# Altium schematic sheet Sheet1.SchDoc(Sheet1)
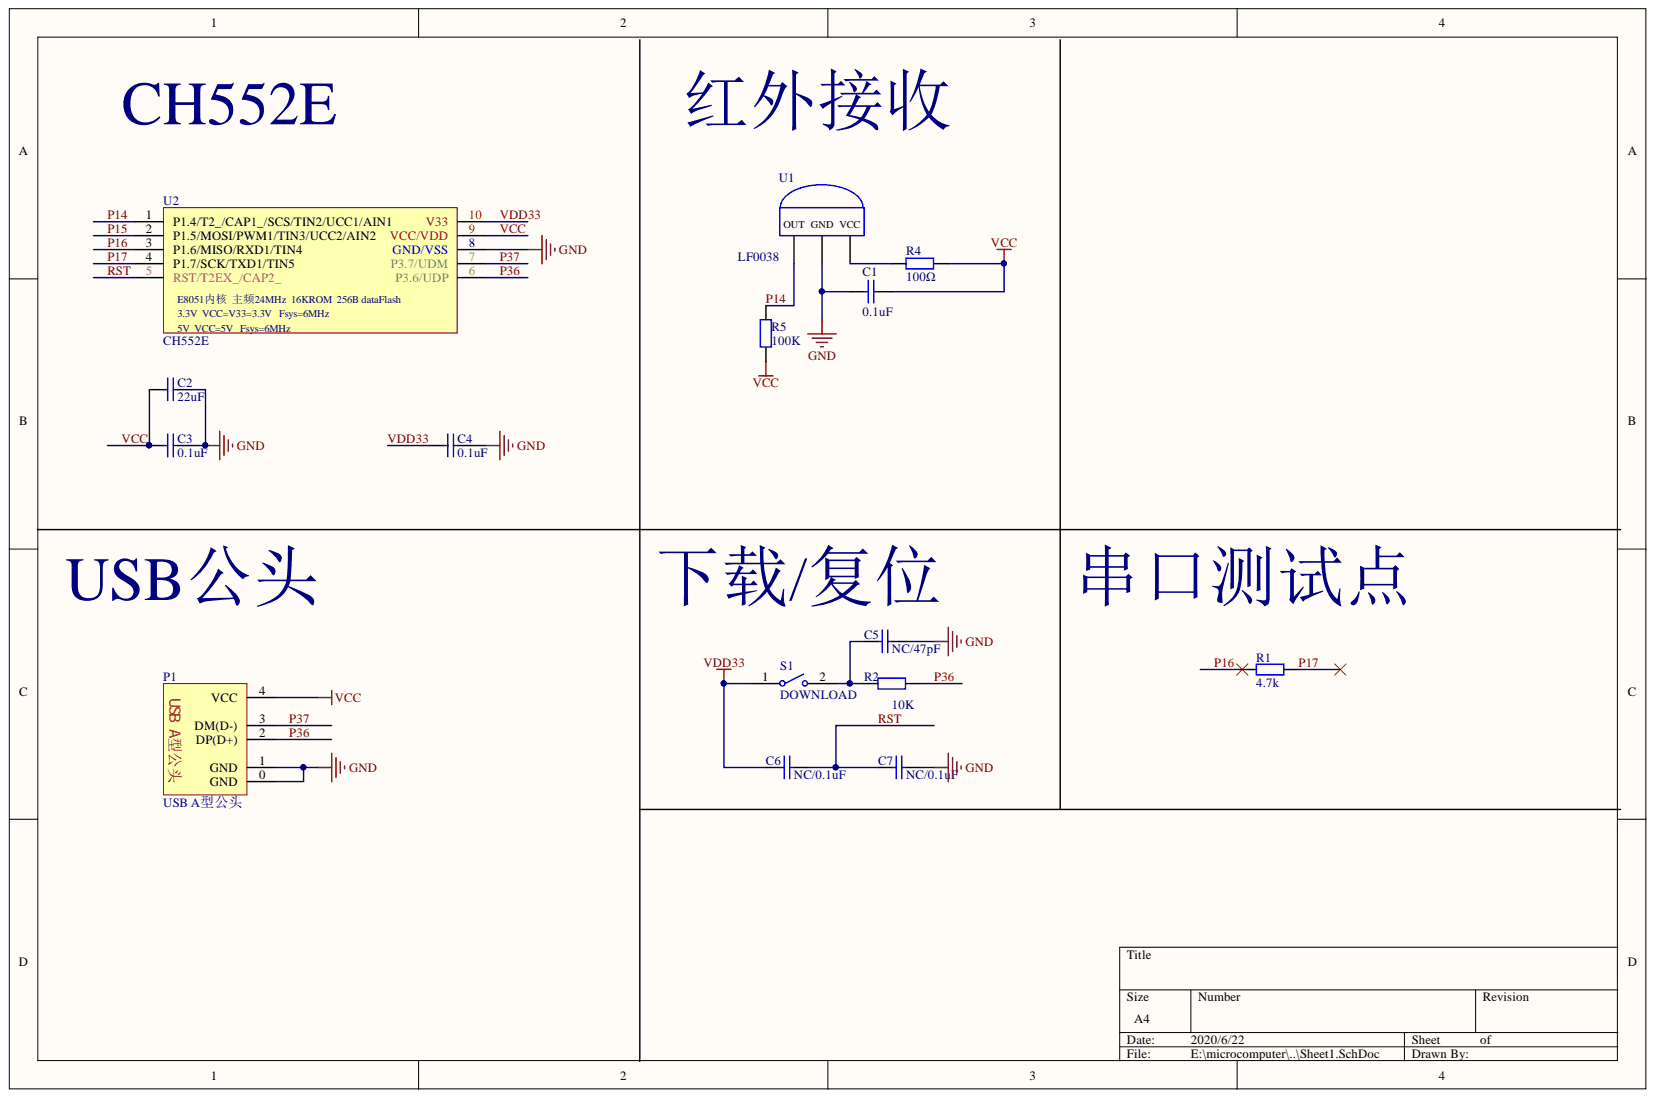
[diagram p0: Sheet1.SchDoc]

## Parts
C1  0.1uF  [0603_C]
C2  22uF  [0805_C]
C3  0.1uF  [0603_C]
C4  0.1uF  [0603_C]
C5  NC/47pF  [0603_C]
C6  NC/0.1uF  [0603_C]
C7  NC/0.1uF  [0603_C]
P1  USB A型公头  [USB_A型公头双面插]
R1  4.7k  [0603_R]
R2  10K  [0603_R]
R4  100Ω  [0603_R]
R5  100K  [0603_R]
S1  DOWNLOAD  [Switch_3*4*2]
U1  LF0038  [4mm贴片红外接收头]
U2  CH552E  [MSOP-10]

## Nets
GND: C1.2 C2.2 C3.2 C4.2 C5.2 C7.2 P1.0(GND) P1.1(GND) U1.2 U2.8(GND/VSS)
P14: R5.2 U1.3 U2.1(P1.4/T2_/CAP1_/SCS/TIN2/UCC1/AIN1)
P15: U2.2(P1.5/MOSI/PWM1/TIN3/UCC2/AIN2)
P16: R1.1 U2.3(P1.6/MISO/RXD1/TIN4)
P17: R1.2 U2.4(P1.7/SCK/TXD1/TIN5)
P36: P1.2(DP(D+)) R2.2 U2.6(P3.6/UDP)
P37: P1.3(DM(D-)) U2.7(P3.7/UDM)
RST: C6.2 C7.1 U2.5(RST/T2EX_/CAP2_)
VCC: C1.1 C2.1 C3.1 P1.4(VCC) R4.2 R5.1 U2.9(VCC/VDD)
VDD33: C4.1 C6.1 S1.1 U2.10(V33)
NetC5_1: C5.1 R2.1 S1.2
NetR4_1: R4.1 U1.1

## Library data of the parts
C1-C7 = Cap: Value: 100pF; Published: 8-Jun-2000; PackageReference: RAD-0.3; PackageDescription: Radial Cap, Thru-Hole; 2 Leads; 0.3 in Pin Spacing; LatestRevisionNote: Re-released for DXP Platform.; LatestRevisionDate: 17-Jul-2002; Component Kind: Standard; Publisher: Altium Limited; Library Name: 基础元件库.SchLib
R1 R2 R4 R5 = Res: 电阻; Value: 1K; Published: 8-Jun-2000; PackageReference: AXIAL-0.4; PackageDescription: Axial Device, Thru-Hole; 2 Leads; 0.4 in Pin Spacing; LatestRevisionNote: Re-released for DXP Platform.; LatestRevisionDate: 17-Jul-2002; Component Kind: Standard; Publisher: Altium Limited
  R1: Library Name: PCB_Project.IntLib
  R2 R4 R5: Library Name: 基础元件库.SchLib
S1 = Switch: Published: 24-Mar-1999; PackageReference: SPST-2; PackageDescription: Switch; 2 Leads; LatestRevisionNote: Re-released for DXP Platform.; LatestRevisionDate: 17-Jul-2002; Component Kind: Standard; Publisher: Altium Limited; Library Name: 基础元件库.SchLib
U2 = CH552E MSOP10: 10-bit; Library Name: MCU.SchLib; Component Kind: Standard
P1 = USB A型公头: Component Kind: Standard; Library Name: 基础元件库.SchLib
U1 = LF0038: Component Kind: Standard; Library Name: 基础元件库.SchLib
